# Altium schematic sheet sensor-5.SchDoc(sensor-5)
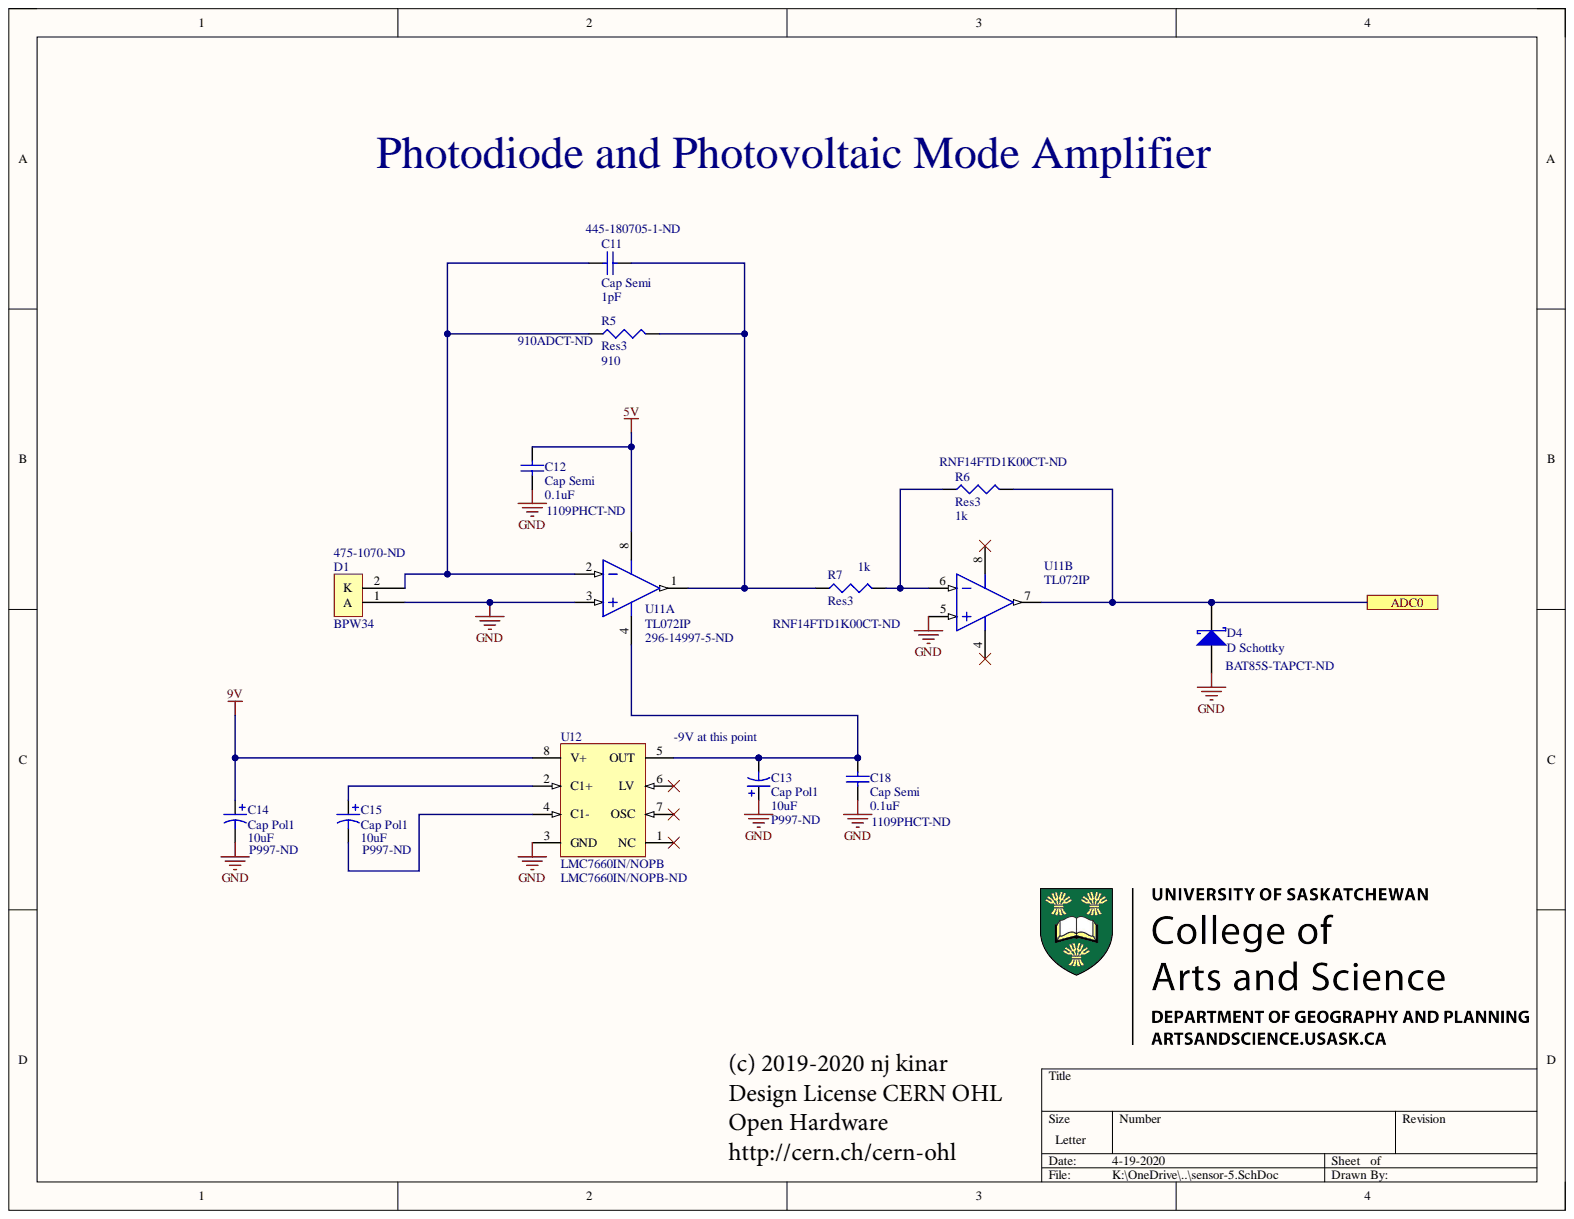
[diagram p0: sensor-5.SchDoc]

## Parts
C11  Cap Semi  value 1pF  Capacitance: 1pF  Supplier Part Number 1: 445-180705-1-ND  [FA28C0G1H010CNU06]
C12  Cap Semi  value 0.1uF  [A104K15X7RF5TAA]
C13  Cap Pol1  value 10uF  Capacitance: 10uF  Supplier Part Number 1: P997-ND  [ECE-A1HKS100]
C14  Cap Pol1  value 10uF  Capacitance: 10uF  Supplier Part Number 1: P997-ND  [ECE-A1HKS100]
C15  Cap Pol1  value 10uF  Capacitance: 10uF  Supplier Part Number 1: P997-ND  [ECE-A1HKS100]
C18  Cap Semi  value 0.1uF  Supplier Part Number 1: 1109PHCT-ND  [A104K15X7RF5TAA]
D1  BPW34  Supplier Part Number 1: 475-1070-ND  [BPW34]
D4  D Schottky  Supplier Part Number 1: BAT85S-TAPCT-ND  [BAT85S-TAP]
R5  Res3  value 910  Supplier Part Number 1: 910ADCT-ND  [MFP-25BRD52-910R]
R6  Res3  value 1k  Supplier Part Number 1: RNF14FTD1K00CT-ND  [RNF14FTD1K00]
R7  Res3  value 1k  Supplier Part Number 1: RNF14FTD1K00CT-ND  [RNF14FTD1K00]
U11  TL072IP  Supplier Part Number 1: 296-14997-5-ND  [P0008A]  (parts A,B)
U12  LMC7660IN/NOPB  Supplier Part Number 1: LMC7660IN/NOPB-ND  [P0008A]

## Ports
ADC0

## Nets
5V: C12.2 U11.8
9V: C14.1 U12.8
GND: C12.1 C13.1 C14.2 C18.1 D1.1 D4.1 U11.3 U11.5 U12.3
ADC0: D4.2 R6.2 U11.7 ; port ADC0
NetC11_1: C11.1 D1.2 R5.1 U11.2
NetC11_2: C11.2 R5.2 R7.1 U11.1
NetC13_2: C13.2 C18.2 U11.4 U12.5
NetC15_1: C15.1 U12.2
NetC15_2: C15.2 U12.4
NetR6_1: R6.1 R7.2 U11.6

Not connected: U12 1 6 7

## Library data of the parts
C11 = Cap Semi: Capacitor (Semiconductor SIM Model); Manufacturer: TDK; Lead/Base Style: Kinked; Voltage Rating: 50V; Case/Package: Radial; Component Kind: Standard; ComponentLink1Description: https://product.tdk.com/info/en/catalog/datasheets/leadmlcc_halogenfree_fa_en.pdf?src-supplier=Digi-Key; ComponentLink1URL: https://product.tdk.com/info/en/catalog/datasheets/leadmlcc_halogenfree_fa_en.pdf?src-supplier=Digi-Key; Height - Seated (Max): 0.217inch; LatestRevisionDate: 29-May-2009; LatestRevisionNote: IPC-7351 Footprint Added.; Lead Pitch: 0.197inch; Length: 0.157inch; Library Name: Miscellaneous Devices.IntLib; Manufacturer Part Number: FA28C0G1H010CNU06; Max Operating Temperature: 125°C; Min Operating Temperature: -55°C; Mount: Through Hole; PackageDescription: Chip Capacitor; PackageReference: C1206; Packaging: Tape and Reel; Pricing 1: 1=0.32000, 10=0.22100, 100=0.12520, 500=0.08840, 1000=0.07735 (USD); Published: 8-Jun-2000; Ratings: AEC-Q200; Signal Integrity: Cap Semi; Simulation: CAP; Stock 1: 7819; Supplier 1: Digi-Key; Width: 0.098inch; Publisher: Altium Limited
C12 C18 = Cap Semi: Capacitor (Semiconductor SIM Model); Manufacturer: Vishay BCcomponents; Capacitance: 100nF; Dielectric: X7R; Lead Diameter: 0.5mm; Lead Length: 24.3mm; Tolerance: 10%; Voltage: 50V; Case/Package: Dipped; Component Kind: Standard; ComponentLink1Description: https://datasheet.ciiva.com/145/aseries-145936.pdf?src-supplier=Digi-Key; ComponentLink1URL: https://datasheet.ciiva.com/145/aseries-145936.pdf?src-supplier=Digi-Key; ComponentLink2Description: https://datasheet.ciiva.com/5815/1734588-5815375.pdf?src-supplier=Farnell; ComponentLink2URL: https://datasheet.ciiva.com/5815/1734588-5815375.pdf?src-supplier=Farnell; ComponentLink3Description: https://datasheet.ciiva.com/18442/70122957-18442089.pdf?src-supplier=Allied+Electronics; ComponentLink3URL: https://datasheet.ciiva.com/18442/70122957-18442089.pdf?src-supplier=Allied+Electronics; ComponentLink4Description: https://datasheet.ciiva.com/2988/aseries-2988250.pdf?src-supplier=Avnet; ComponentLink4URL: https://datasheet.ciiva.com/2988/aseries-2988250.pdf?src-supplier=Avnet; ComponentLink5Description: https://datasheet.ciiva.com/17444/aseries-17444908.pdf?src-supplier=Avnet+Europe; ComponentLink5URL: https://datasheet.ciiva.com/17444/aseries-17444908.pdf?src-supplier=Avnet+Europe; ComponentLink6Description: https://datasheet.ciiva.com/46418/1796772-46418252.pdf?src-supplier=Element14; ComponentLink6URL: https://datasheet.ciiva.com/46418/1796772-46418252.pdf?src-supplier=Element14; ComponentLink7Description: https://datasheet.ciiva.com/145/aseries-145935.pdf?src-supplier=Digi-Key; ComponentLink7URL: https://datasheet.ciiva.com/145/aseries-145935.pdf?src-supplier=Digi-Key; Contact Plating: Tin; Diameter: 2.5mm; LatestRevisionDate: 29-May-2009; LatestRevisionNote: IPC-7351 Footprint Added.; Lead Free: Lead Free; Length: 3.8mm; Library Name: Miscellaneous Devices.IntLib; Manufacturer Part Number: A104K15X7RF5TAA; Max Operating Temperature: 125°C; Min Operating Temperature: -55°C; Mount: Through Hole,PCB,Axial; Package Quantity: 1; PackageDescription: Chip Capacitor; PackageReference: C1206; Packaging: Tape and Reel; Pricing 1: 1=0.24000, 10=0.16500, 100=0.09350, 500=0.06598, 1000=0.05773, 2500=0.05498 (USD); Published: 8-Jun-2000; Radiation Hardening: No; REACH SVHC: Unknown; RoHS: Yes; Signal Integrity: Cap Semi; Simulation: CAP; Stock 1: 502711; Supplier 1: Digi-Key; Termination: Axial; Voltage Rating: 50V; Voltage Rating (DC): 50V; Publisher: Altium Limited
  C12: Supplier Part Number 1: 1109PHCT-ND
C13-C15 = Cap Pol1: Polarized Capacitor (Radial); Manufacturer: Panasonic; Lead Diameter: 0.45mm; Leakage Current: 3A; Life (Hours): 1000Hour; Polarity: Polar; Ripple Current: 35mA; Tolerance: 20%; Voltage: 50V; Case/Package: Radial; Component Kind: Standard; ComponentLink1Description: https://datasheet.ciiva.com/11778/0900766b800274c4-11778985.pdf?src-supplier=RSComponents; ComponentLink1URL: https://datasheet.ciiva.com/11778/0900766b800274c4-11778985.pdf?src-supplier=RSComponents; ComponentLink2Description: https://datasheet.ciiva.com/17536/aba0000ce15-17536597.pdf?src-supplier=Avnet+Europe; ComponentLink2URL: https://datasheet.ciiva.com/17536/aba0000ce15-17536597.pdf?src-supplier=Avnet+Europe; ComponentLink3Description: https://datasheet.ciiva.com/14594/70067878-14594328.pdf?src-supplier=Allied+Electronics; ComponentLink3URL: https://datasheet.ciiva.com/14594/70067878-14594328.pdf?src-supplier=Allied+Electronics; ComponentLink4Description: https://datasheet.ciiva.com/16205/aba0000ce15-16205737.pdf?src-supplier=Future+Electronics; ComponentLink4URL: https://datasheet.ciiva.com/16205/aba0000ce15-16205737.pdf?src-supplier=Future+Electronics; ComponentLink5Description: https://datasheet.ciiva.com/24025/a-e-461514-24025641.pdf?src-supplier=Mouser; ComponentLink5URL: https://datasheet.ciiva.com/24025/a-e-461514-24025641.pdf?src-supplier=Mouser; ComponentLink6Description: https://datasheet.ciiva.com/43459/1339471-43459545.pdf?src-supplier=Farnell; ComponentLink6URL: https://datasheet.ciiva.com/43459/1339471-43459545.pdf?src-supplier=Farnell; ComponentLink7Description: https://datasheet.ciiva.com/15166/getdatasheetpartid-169217-15166123.pdf?src-supplier=Verical; ComponentLink7URL: https://datasheet.ciiva.com/15166/getdatasheetpartid-169217-15166123.pdf?src-supplier=Verical; ComponentLink8Description: https://datasheet.ciiva.com/46397/1339471-46397117.pdf?src-supplier=Element14; ComponentLink8URL: https://datasheet.ciiva.com/46397/1339471-46397117.pdf?src-supplier=Element14; ComponentLink9Description: https://datasheet.ciiva.com/2983/aba0000ce15-2983886.pdf?src-supplier=Avnet; ComponentLink9URL: https://datasheet.ciiva.com/2983/aba0000ce15-2983886.pdf?src-supplier=Avnet; Diameter: 6.3mm; Height: 5mm; Height - Seated (Max): 0.197inch; LatestRevisionDate: 17-Jul-2002; LatestRevisionNote: Re-released for DXP Platform.; Lead Free: Lead Free; Lead Pitch: 2.5mm; Length: 5mm; Library Name: Miscellaneous Devices.IntLib; Manufacturer Part Number: ECE-A1HKS100; Max Operating Temperature: 85°C; Min Operating Temperature: -40°C; Mount: Through Hole,PCB; Number of Pins: 2; Package Quantity: 200; PackageDescription: Polarized Capacitor (Radial); 2 Leads; PackageReference: RB7.6-15; Packaging: Bulk; Pricing 1: 1=0.10000, 10=0.03000 (USD); Published: 8-Jun-2000; Radiation Hardening: No; REACH SVHC: No SVHC; Ripple Current (AC): 35mA; RoHS: Yes; Signal Integrity: Cap Pol; Simulation: CAP; Stock 1: 132836; Supplier 1: Digi-Key; Termination: Radial; Voltage Rating: 50V; Voltage Rating (DC): 50V; Weight: 0.01lb; Width: 0.5inch; Publisher: Altium Limited
D1 = BPW34: Manufacturer: Osram Opto; Dark Current: 30nA; Fall Time: 20ns; Forward Voltage: 1.3V; Polarity: Reverse; Power Dissipation: 150mW; Reverse Breakdown Voltage: 32V; Wavelength: 850nm; Component Kind: Standard; ComponentLink1Description: https://datasheet.ciiva.com/19453/1621132-19453495.pdf?src-supplier=Jameco; ComponentLink1URL: https://datasheet.ciiva.com/19453/1621132-19453495.pdf?src-supplier=Jameco; ComponentLink2Description: https://datasheet.ciiva.com/11772/0900766b80a15a67-11772491.pdf?src-supplier=RSComponents; ComponentLink2URL: https://datasheet.ciiva.com/11772/0900766b80a15a67-11772491.pdf?src-supplier=RSComponents; ComponentLink3Description: https://datasheet.ciiva.com/26883/getdatasheetpartid-571168-26883163.pdf?src-supplier=Verical; ComponentLink3URL: https://datasheet.ciiva.com/26883/getdatasheetpartid-571168-26883163.pdf?src-supplier=Verical; ComponentLink4Description: https://datasheet.ciiva.com/29138/153005-da-01-ml-pin-photodiode-bpw34-osr-de-en-29138768.pdf?src-supplier=Conrad; ComponentLink4URL: https://datasheet.ciiva.com/29138/153005-da-01-ml-pin-photodiode-bpw34-osr-de-en-29138768.pdf?src-supplier=Conrad; Description: BPW34; Library Name: osram.IntLib; Manufacturer Part Number: BPW34; Max Operating Temperature: 100°C; Min Operating Temperature: -40°C; Mount: Through Hole; Number of Pins: 2; Packaging: Bulk; Pricing 1: 1=1.05000, 10=0.81700, 100=0.52550, 500=0.44374, 1000=0.35033, 2500=0.32697, 5000=0.31529, 10000=0.31062, 25000=0.30362 (USD); Radiation Hardening: No; Rise Time: 20ns; RoHS: Yes; Stock 1: 40998; Supplier 1: Digi-Key
D4 = D Schottky: Schottky Diode; Manufacturer: Vishay; Average Rectified Current: 200mA; Element Configuration: Single; Forward Voltage: 800mV; Max Forward Surge Current (Ifsm): 5A; Max Repetitive Reverse Voltage (Vrrm): 30V; Max Reverse Leakage Current: 2uA; Recovery Time: 5ns; Code_JEDEC: DO-214-AA; Component Kind: Standard; ComponentLink1Description: https://datasheet.ciiva.com/23785/1749004-23785297.pdf?src-supplier=Newark; ComponentLink1URL: https://datasheet.ciiva.com/23785/1749004-23785297.pdf?src-supplier=Newark; ComponentLink2Description: https://datasheet.ciiva.com/17078/bat85s-tap-17078427.pdf?src-supplier=Allied+Electronics; ComponentLink2URL: https://datasheet.ciiva.com/17078/bat85s-tap-17078427.pdf?src-supplier=Allied+Electronics; ComponentLink3Description: https://datasheet.ciiva.com/15144/getdatasheetpartid-50689-15144221.pdf?src-supplier=Verical; ComponentLink3URL: https://datasheet.ciiva.com/15144/getdatasheetpartid-50689-15144221.pdf?src-supplier=Verical; ComponentLink4Description: https://datasheet.ciiva.com/16418/bat85s-16418454.pdf?src-supplier=Future+Electronics; ComponentLink4URL: https://datasheet.ciiva.com/16418/bat85s-16418454.pdf?src-supplier=Future+Electronics; ComponentLink5Description: https://datasheet.ciiva.com/25931/bat85s-25931304.pdf?src-supplier=Avnet+Europe; ComponentLink5URL: https://datasheet.ciiva.com/25931/bat85s-25931304.pdf?src-supplier=Avnet+Europe; ComponentLink6Description: https://datasheet.ciiva.com/13950/bat85s-13950093.pdf?src-supplier=Digi-Key; ComponentLink6URL: https://datasheet.ciiva.com/13950/bat85s-13950093.pdf?src-supplier=Digi-Key; ComponentLink7Description: https://datasheet.ciiva.com/13950/bat85s-13950090.pdf?src-supplier=Digi-Key; ComponentLink7URL: https://datasheet.ciiva.com/13950/bat85s-13950090.pdf?src-supplier=Digi-Key; ComponentLink8Description: https://datasheet.ciiva.com/8283/bat85s-8283915.pdf?src-supplier=Avnet; ComponentLink8URL: https://datasheet.ciiva.com/8283/bat85s-8283915.pdf?src-supplier=Avnet; Contact Plating: Tin,Silver; Forward Current: 200mA; Height: 1.7mm; LatestRevisionDate: 17-Jul-2002; LatestRevisionNote: Re-released for DXP Platform.; Lead Free: Lead Free; Length: 3.9mm; Library Name: Miscellaneous Devices.IntLib; Manufacturer Part Number: BAT85S-TAP; Max Operating Temperature: 125°C; Max Reverse Voltage (DC): 30V; Max Surge Current: 5A; Min Operating Temperature: -65°C; Mount: Through Hole; Number of Pins: 2; Package Quantity: 10000; PackageDescription: DO-214-AA/SMB; 2 C-Bend Leads; Body 5.3 x 3.6 mm, inc. leads (LxW); PackageReference: SMB; Packaging: Ammo Pack; Peak Non-Repetitive Surge Current: 5A; Peak Reverse Current: 2uA; Pricing 1: 1=0.38000, 10=0.31000, 100=0.16440, 500=0.10816, 1000=0.07354, 2500=0.06633, 5000=0.05768 (USD); Published: 8-Jun-2000; Radiation Hardening: No; Reverse Recovery Time: 5ns; RoHS: Yes; Signal Integrity: D Schottky; Simulation: SKYDIODE; Stock 1: 54447; Supplier 1: Digi-Key; Width: 1.7mm; Publisher: Altium Limited
R5 = Res3: Resistor; Manufacturer: Yageo; Max Power Dissipation: 250mW; Resistance: 910R; Temperature Coefficient: 25ppm/°C; Tolerance: 0.1%; Case/Package: Axial; Component Kind: Standard; ComponentLink1Description: https://datasheet.ciiva.com/2713/yageolr-mfp-2013-2713082.pdf?src-supplier=Digi-Key; ComponentLink1URL: https://datasheet.ciiva.com/2713/yageolr-mfp-2013-2713082.pdf?src-supplier=Digi-Key; ComponentLink2Description: https://datasheet.ciiva.com/2713/yageolr-mfp-2013-2713083.pdf?src-supplier=Digi-Key; ComponentLink2URL: https://datasheet.ciiva.com/2713/yageolr-mfp-2013-2713083.pdf?src-supplier=Digi-Key; Composition: Metal Film; LatestRevisionDate: 29-May-2009; LatestRevisionNote: IPC-7351 Footprint Added.; Lead Free: Lead Free; Length: 0.248inch; Library Name: Miscellaneous Devices.IntLib; Manufacturer Part Number: MFP-25BRD52-910R; Max Operating Temperature: 155°C; Min Operating Temperature: -55°C; Number of Terminations: 2; Package Quantity: 1; PackageDescription: Chip Resistor; PackageReference: J1-0603; Packaging: Tape and Reel; Pricing 1: 1=0.63000, 10=0.46700, 25=0.37920, 50=0.32960, 100=0.27190, 250=0.23624, 500=0.19228, 1000=0.15250 (USD); Published: 8-Jun-2000; RoHS: Yes; Signal Integrity: Res; Simulation: RESISTOR; Stock 1: 18352; Supplier 1: Digi-Key; Publisher: Altium Limited
R6 R7 = Res3: Resistor; Manufacturer: Stackpole Electronics; Max Power Dissipation: 250mW; Resistance: 1kR; Temperature Coefficient: 100ppm/°C; Tolerance: 1%; Case/Package: Conformal; Component Kind: Standard; ComponentLink1Description: http://components.arrow.com/part/dataview/50573548S9848899N1174?src-supplier=Arrow; ComponentLink1URL: http://components.arrow.com/part/dataview/50573548S9848899N1174?src-supplier=Arrow; ComponentLink2Description: https://www.seielect.com/Catalog/SEI-RNF_RNMF.pdf?src-supplier=Avnet; ComponentLink2URL: https://www.seielect.com/Catalog/SEI-RNF_RNMF.pdf?src-supplier=Avnet; ComponentLink3Description: https://www.seielect.com/Catalog/SEI-RNF_RNMF.pdf?src-supplier=Digi-Key; ComponentLink3URL: https://www.seielect.com/Catalog/SEI-RNF_RNMF.pdf?src-supplier=Digi-Key; ComponentLink4Description: https://www.verical.com/server-webapp/html/getDatasheet?partID=360720&src-supplier=Verical; ComponentLink4URL: https://www.verical.com/server-webapp/html/getDatasheet?partID=360720&src-supplier=Verical; ComponentLink5Description: http://www.seielect.com/Catalog/SEI-RNF_RNMF.pdf?src-supplier=Future+Electronics; ComponentLink5URL: http://www.seielect.com/Catalog/SEI-RNF_RNMF.pdf?src-supplier=Future+Electronics; Composition: Metal Film; Contact Plating: Copper,Tin; Diameter: 2.35mm; Features: Flame Retardant Coating; LatestRevisionDate: 29-May-2009; LatestRevisionNote: IPC-7351 Footprint Added.; Lead Free: Lead Free; Length: 6.35mm; Library Name: Miscellaneous Devices.IntLib; Manufacturer Part Number: RNF14FTD1K00; Max Operating Temperature: 155°C; Military Standard: Not; Min Operating Temperature: -55°C; Mount: Through Hole,Axial; Number of Terminations: 2; Package Quantity: 1; PackageDescription: Chip Resistor; PackageReference: J1-0603; Packaging: Tape and Reel; Power Rating: 250mW; Pricing 1: 1=0.10000, 10=0.07200, 25=0.04960, 50=0.03860, 100=0.02750, 250=0.02144, 500=0.01706, 1000=0.01210 (USD); Published: 8-Jun-2000; Radiation Hardening: No; RoHS: Yes; Signal Integrity: Res; Simulation: RESISTOR; Stock 1: 259426; Supplier 1: Digi-Key; Termination: Axial; Publisher: Altium Limited
U11 = CMP-0017-00928-2: Dual Low-Noise JFET-Input General-Purpose Operational Amplifier, 7 to 36 V, -40 to 85 degC, 8-pin DIP (P8), Pb-Free (RoHS); Manufacturer: Texas Instruments; Bandwidth: 3MHz; CMRR(Min)(dB): 75; Common Mode Rejection Ratio: 75dB; Dual Supply Voltage: 9V; GBW(Typ)(MHz): 3; IIB(Max)(pA): 200; Input Bias Current: 200pA; Input Offset Voltage (Vos): 6mV; Iq per channel(Max)(mA): 2.5; Max Dual Supply Voltage: 18V; Min Dual Supply Voltage: 3.5V; Nominal Supply Current: 5mA; Number of Amplifiers: 2; Offset Drift(Typ)(uV/C): 18; Operating Supply Current: 1.4mA; Rating: Catalog; Slew Rate: 13V/µs; Slew Rate(Typ)(V/us): 13; Sub Family: General Purpose Amplifier, High Supply Voltage Amplifier (>=30V); Total Supply Voltage(Max)(+5V is 5, +/-5V is 10): 36; Total Supply Voltage(Min)(+5V is 5, +/-5V is 10): 7; Vio (25C)(Max)(mV): 6; Voltage Gain: 106.02dB; Case/Package: P; Code_JEDEC: MS-001; Component Kind: Standard; ComponentLink1Description: Manufacturer URL; ComponentLink1URL: http://www.ti.com/; ComponentLink2Description: Datasheet; ComponentLink2URL: http://www.ti.com/lit/ds/symlink/tl072.pdf; ComponentLink3Description: Package Specification; ComponentLink3URL: http://www.ti.com/litv/pdf/mpdi001b; ComponentLink4Description: https://datasheet.ciiva.com/26847/tl072ip-26847615.pdf?src-supplier=IIIC; ComponentLink4URL: https://datasheet.ciiva.com/26847/tl072ip-26847615.pdf?src-supplier=IIIC; ComponentLink5Description: https://datasheet.ciiva.com/2974/tl074-2974210.pdf?src-supplier=Avnet; ComponentLink5URL: https://datasheet.ciiva.com/2974/tl074-2974210.pdf?src-supplier=Avnet; ComponentLink6Description: https://datasheet.ciiva.com/7738/1847488-7738549.pdf?src-supplier=Element14; ComponentLink6URL: https://datasheet.ciiva.com/7738/1847488-7738549.pdf?src-supplier=Element14; ComponentLink7Description: https://datasheet.ciiva.com/26244/tl074-26244104.pdf?src-supplier=Avnet+Europe; ComponentLink7URL: https://datasheet.ciiva.com/26244/tl074-26244104.pdf?src-supplier=Avnet+Europe; ComponentLink8Description: https://datasheet.ciiva.com/1797/tl071a-1797084.pdf?src-supplier=Digi-Key; ComponentLink8URL: https://datasheet.ciiva.com/1797/tl071a-1797084.pdf?src-supplier=Digi-Key; DatasheetVersion: SLOS080J; Eco-Plan: Pb-Free (RoHS); Gain Bandwidth Product: 3MHz; Height: 4.57mm; Lead Free: Lead Free; Length: 9.81mm; Library Name: Altium Content Vault; Manufacturer Part Number: TL072IP; Max I/O Voltage: 6mV; Max Operating Temperature: 85°C; Min Operating Temperature: -40°C; Mounting Technology: Through Hole; Nominal Gain Bandwidth Product: 3MHz; Number of Channels: 2; Number of Circuits: 2; Number of Elements: 2; Number of Pins: 8; Operating Supply Voltage: 18V; Package Quantity: 50; PackageDescription: 8-Pin Plastic In-Line, Body 9.59 x 6.35 mm, Pitch 2.54 mm; PackageReference: P0008A; PackageVersion: 4040082/E, 04/2010; Packaging: Rail/Tube; PartNumber: TL072IP; Pricing 1: 1=0.69000, 10=0.60700, 25=0.57040, 100=0.46550, 500=0.36800, 1000=0.29440, 2500=0.26680, 5000=0.24840 (USD); Radiation Hardening: No; REACH SVHC: No SVHC; RoHS: Yes; Stock 1: 1917; Supplier 1: Digi-Key; Unity Gain Bandwidth Product: 3MHz; Vn at 1kHz(Typ)(nV/rtHz): 18; Width: 6.35mm
U12 = CMP-0065-00002-3: Switched Capacitor Voltage Converter, 8-pin MDIP, Pb-Free; Manufacturer: Texas Instruments; CompType: Switch Caps; Frequency: 10kHz; Logic Function: Inverting; Max Input Voltage: 10V; Max Output Voltage: -1.5V; Min Input Voltage: 1.5V; Min Output Voltage: -10V; Number of Outputs: 1; Operating Supply Current: 200uA; Output Power: 1.4W; Output Type: Adjustable; PackageTopMarking: NSUZXYTTE#*LMC*7660IN; PowerWise: N; Quiescent Current: 120uA; Case/Package: PDIP; Code_JEDEC: MS-001-BA; Component Kind: Standard; ComponentLink1Description: Datasheet URL; ComponentLink1URL: http://www.ti.com/general/docs/lit/getliterature.tsp?genericPartNumber=LMC7660&fileType=pdf; ComponentLink2Description: https://datasheet.ciiva.com/25627/0900766b80b14545-25627686.pdf?src-supplier=RSComponents; ComponentLink2URL: https://datasheet.ciiva.com/25627/0900766b80b14545-25627686.pdf?src-supplier=RSComponents; ComponentLink3Description: https://datasheet.ciiva.com/41458/001014234-da-01-en-ic-reg-switche-lmc7660in-nopb-pdip-8-tid-41458595.pdf?src-supplier=Conrad; ComponentLink3URL: https://datasheet.ciiva.com/41458/001014234-da-01-en-ic-reg-switche-lmc7660in-nopb-pdip-8-tid-41458595.pdf?src-supplier=Conrad; ComponentLink4Description: https://datasheet.ciiva.com/6598/lmc7660-6598038.pdf?src-supplier=Digi-Key; ComponentLink4URL: https://datasheet.ciiva.com/6598/lmc7660-6598038.pdf?src-supplier=Digi-Key; Height: 3.3mm; Lead Free: Lead Free; Length: 9.27mm; Library Name: Altium Content Vault; Manufacturer Part Number: LMC7660IN/NOPB; Max Operating Temperature: 85°C; Max Supply Voltage: 10V; Min Operating Temperature: -40°C; Min Supply Voltage: 1.5V; Mount: Through Hole; Number of Pins: 8; Output Voltage: -10V; PackageDescription: MDIP, .365 x .250 x .130in, 8 Lead, .100in Pitch; PackageReference: P0008A; Packaging: Rail/Tube; PinCount: 8; Pricing 1: 1=1.29000, 10=1.15100, 25=1.09200, 100=0.89700, 500=0.74100, 1000=0.58500, 2500=0.54600, 5000=0.51870 (USD); Radiation Hardening: No; REACH SVHC: No SVHC; RoHS: Y; Stock 1: 2555; Supplier 1: Digi-Key; Switching Frequency: 10kHz; Termination: Through Hole; Weight: 0.015521oz; Width: 6.35mm
